# Altium schematic sheet ESSICC-IN-INV1.SchDoc(ESSICC-IN-INV1)
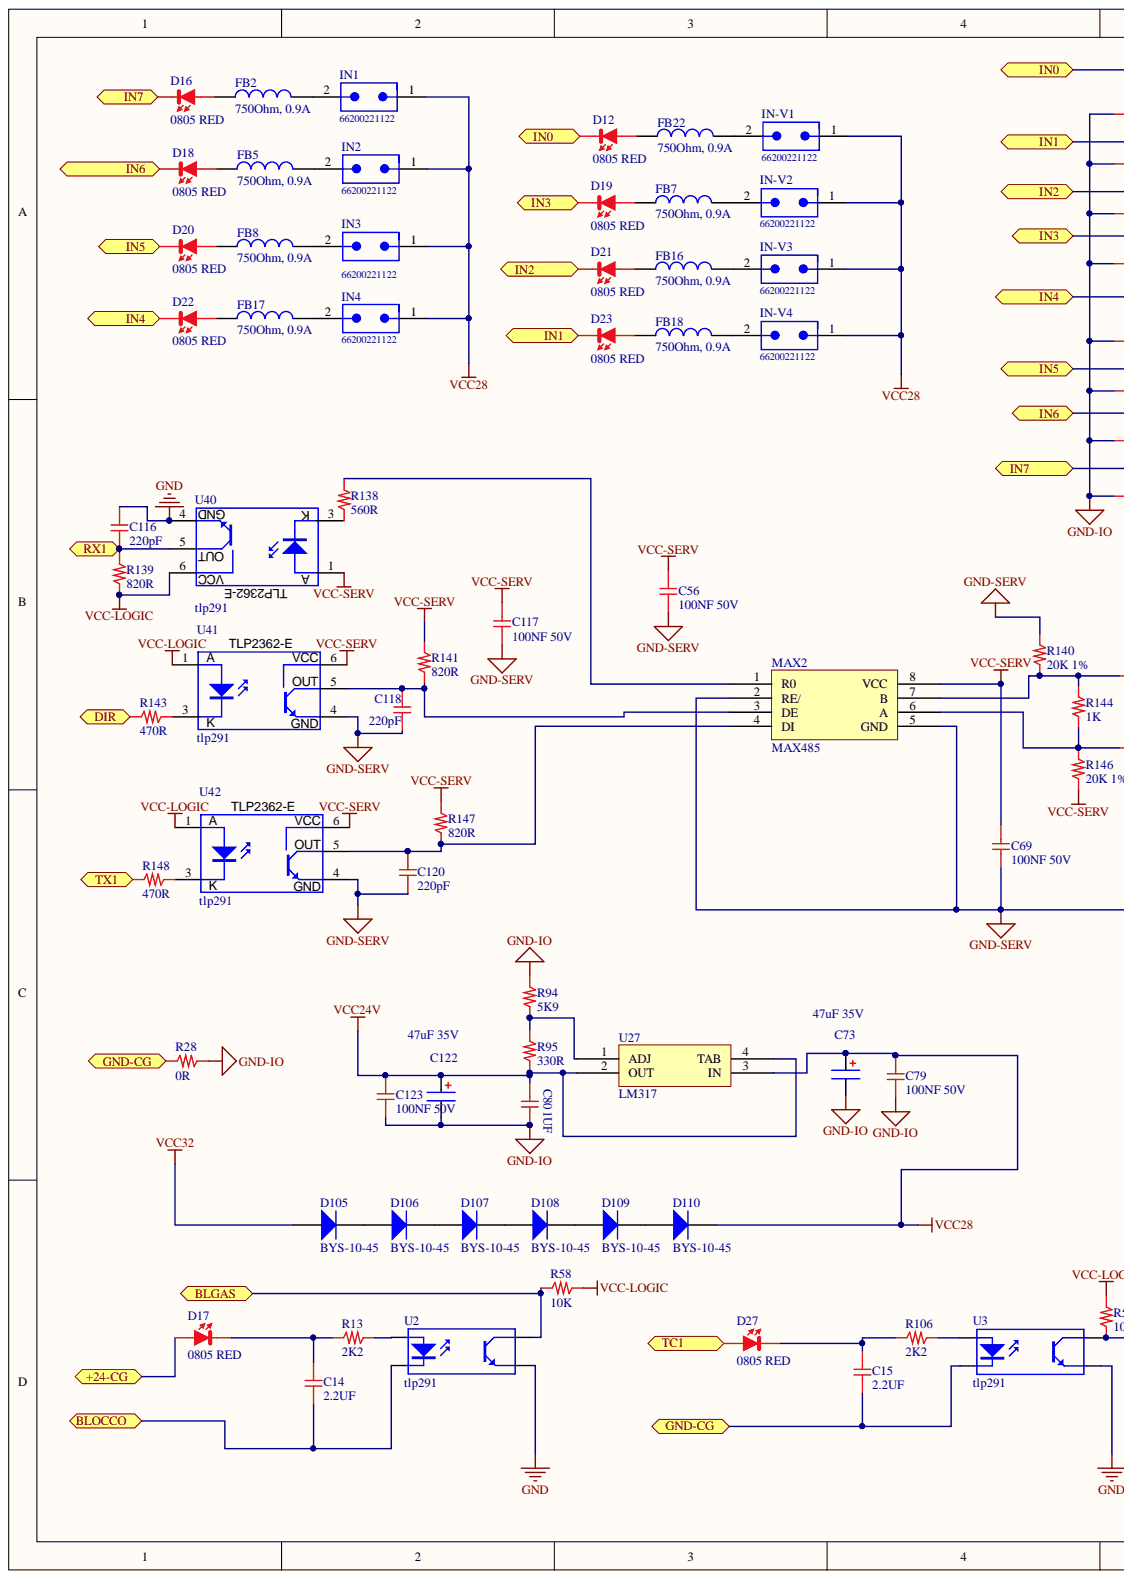
[diagram p0: ESSICC-IN-INV1.SchDoc, left]
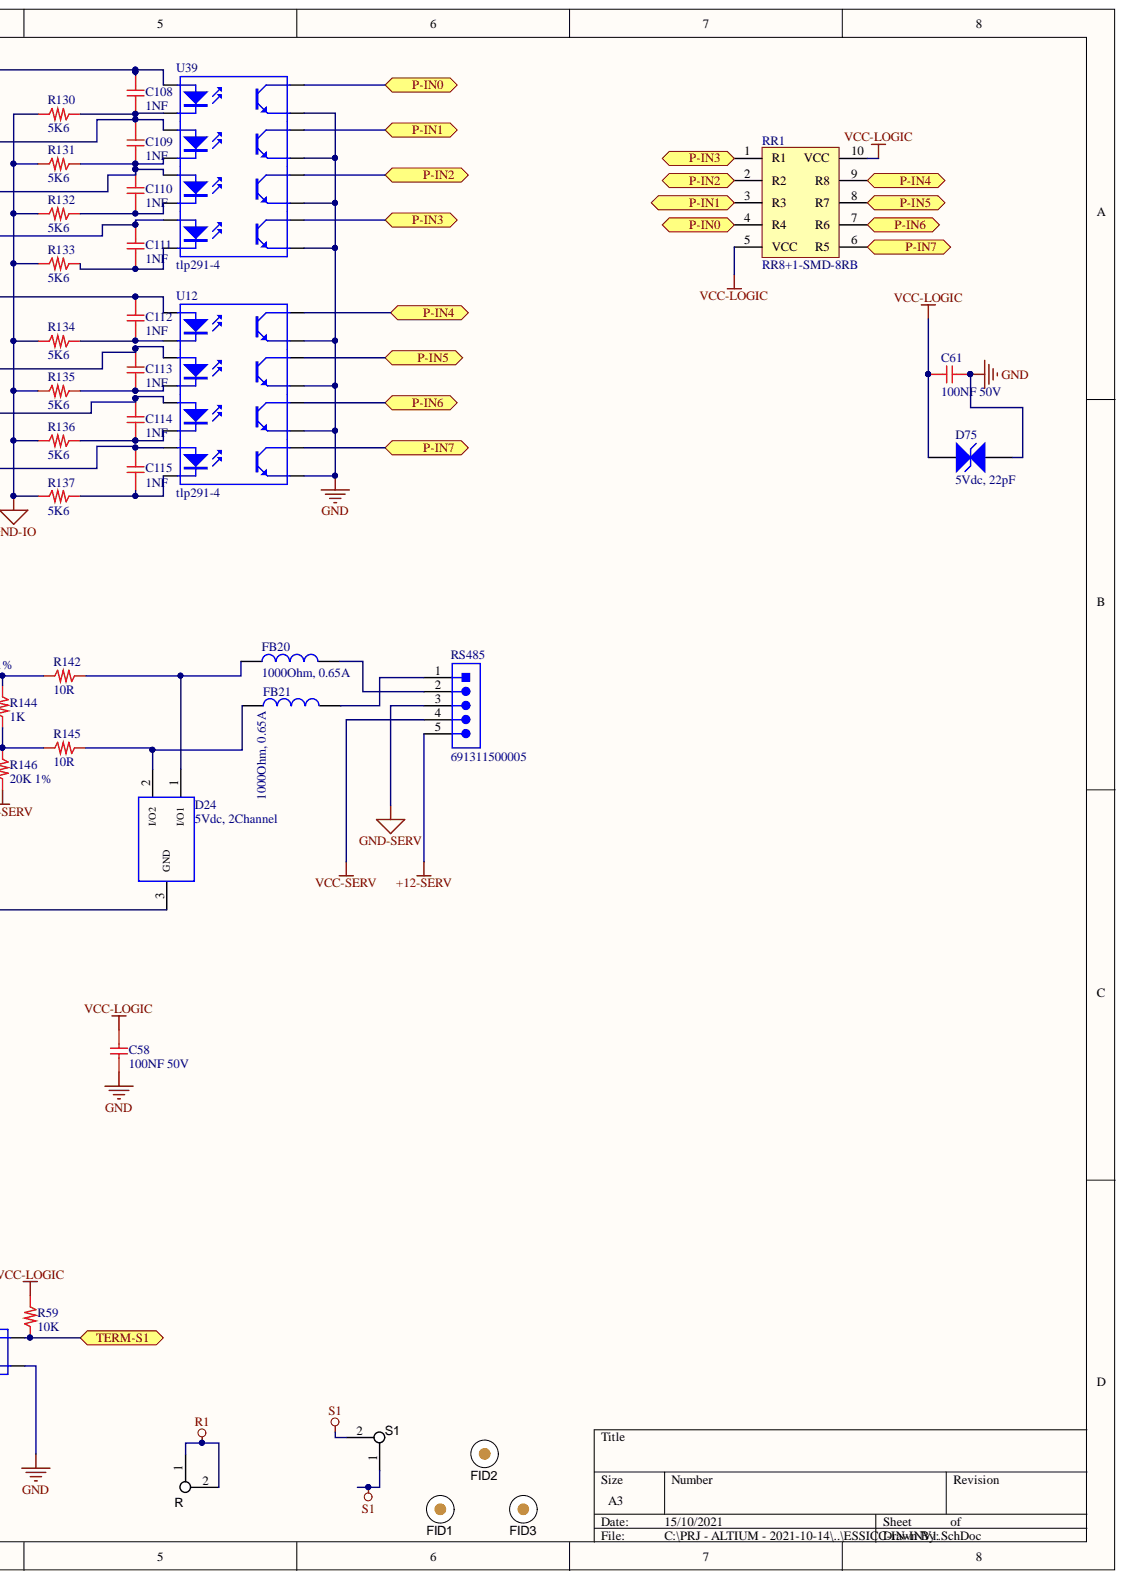
[diagram p1: ESSICC-IN-INV1.SchDoc, right]

## Parts
C14  2.2UF  [1206]
C15  2.2UF  [1206]
C56  100NF 50V  [0603_c]
C58  100NF 50V  [0603_c]
C61  100NF 50V  [0603_c]
C69  100NF 50V  [0603_c]
C73  47uF 35V  Tensione nominale: 35V  Capacità: 47uF  [6.3X5.8]
C79  100NF 50V  [0603_c]
C80  1UF  [0805_C]  (value not drawn)
C108  1NF  [0402_C]
C109  1NF  [0402_C]
C110  1NF  [0402_C]
C111  1NF  [0402_C]
C112  1NF  [0402_C]
C113  1NF  [0402_C]
C114  1NF  [0402_C]
C115  1NF  [0402_C]
C116  220pF  [0402_C]
C117  100NF 50V  [0603_c]
C118  220pF  [0402_C]
C120  220pF  [0402_C]
C122  47uF 35V  Tensione nominale: 35V  Capacità: 47uF  [6.3X5.8]
C123  100NF 50V  [0603_c]
D12  0805 RED  [0805_Red]
D16  0805 RED  [0805_Red]
D17  0805 RED  [0805_Red]
D18  0805 RED  [0805_Red]
D19  0805 RED  [0805_Red]
D20  0805 RED  [0805_Red]
D21  0805 RED  [0805_Red]
D22  0805 RED  [0805_Red]
D23  0805 RED  [0805_Red]
D24  5Vdc, 2Channel  [WE-TVS_Standard_SOT23-3L]
D27  0805 RED  [0805_Red]
D75  5Vdc, 22pF  Capacitance: 22pF  [WE-VE_0603]
D105  BYS-10-45  [DO-214AC -B]
D106  BYS-10-45  [DO-214AC -B]
D107  BYS-10-45  [DO-214AC -B]
D108  BYS-10-45  [DO-214AC -B]
D109  BYS-10-45  [DO-214AC -B]
D110  BYS-10-45  [DO-214AC -B]
FB2  750Ohm, 0.9A  Rated Current: 0.9A  [WE-CBF_0603_Wide Band]
FB5  750Ohm, 0.9A  Rated Current: 0.9A  [WE-CBF_0603_Wide Band]
FB7  750Ohm, 0.9A  Rated Current: 0.9A  [WE-CBF_0603_Wide Band]
FB8  750Ohm, 0.9A  Rated Current: 0.9A  [WE-CBF_0603_Wide Band]
FB16  750Ohm, 0.9A  Rated Current: 0.9A  [WE-CBF_0603_Wide Band]
FB17  750Ohm, 0.9A  Rated Current: 0.9A  [WE-CBF_0603_Wide Band]
FB18  750Ohm, 0.9A  Rated Current: 0.9A  [WE-CBF_0603_Wide Band]
FB20  1000Ohm, 0.65A  Rated Current: 0.65A  [WE-CBF_0603_Wide Band]
FB21  1000Ohm, 0.65A  Rated Current: 0.65A  [WE-CBF_0603_Wide Band]
FB22  750Ohm, 0.9A  Rated Current: 0.9A  [WE-CBF_0603_Wide Band]
FID1  Fiducial  [Fiducial10-20]  (value not drawn)
FID2  Fiducial  [Fiducial10-20]  (value not drawn)
FID3  Fiducial  [Fiducial10-20]  (value not drawn)
IN1  66200221122  [66200221122]
IN2  66200221122  [66200221122]
IN3  66200221122  [66200221122]
IN4  66200221122  [66200221122]
IN-V1  66200221122  [66200221122]
IN-V2  66200221122  [66200221122]
IN-V3  66200221122  [66200221122]
IN-V4  66200221122  [66200221122]
MAX2  MAX485  [SO-8]
R  5012  [FASTOM-TERRA]  (value not drawn)
R13  2K2  [1206_R]
R28  0R  [0805_R]
R58  10K  [0603_R]
R59  10K  [0603_R]
R94  5K9  [0805_R]
R95  330R  [0805_R]
R106  2K2  [1206_R]
R130  5K6  [1206_R]
R131  5K6  [1206_R]
R132  5K6  [1206_R]
R133  5K6  [1206_R]
R134  5K6  [1206_R]
R135  5K6  [1206_R]
R136  5K6  [1206_R]
R137  5K6  [1206_R]
R138  560R  [0603_R]
R139  820R  [0402_R]
R140  20K 1%  [0402_R]
R141  820R  [0402_R]
R142  10R  [0805_R]
R143  470R  [0603_R]
R144  1K  [0805_R]
R145  10R  [0805_R]
R146  20K 1%  [0402_R]
R147  820R  [0402_R]
R148  470R  [0603_R]
RR1  RR8+1-SMD-8RB  [RR8+1-SMD]
RS485  691311500005  [691311500005]
S1  5012  [FASTOM-TERRA]  (value not drawn)
U2  tlp291  [SO4_N]
U3  tlp291  [SO4_N]
U12  tlp291-4  [SO16_NO]
U27  LM317  [SOT-223 - LM317]
U39  tlp291-4  [SO16_NO]
U40  tlp291  [TLP2362-E]
U41  tlp291  [TLP2362-E]
U42  tlp291  [TLP2362-E]

## Ports
+24-CG, BLGAS, BLOCCO, DIR, GND-CG, IN0, IN1, IN2, IN3, IN4, IN5, IN6, IN7, P-IN0, P-IN1, P-IN2, P-IN3, P-IN4, P-IN5, P-IN6, P-IN7, RX1, TC1, TERM-S1, TX1

## Nets
+12-SERV: RS485.5
GND: C58.2 C61.2 C116.1 D75.1 U2.3 U3.3 U12.9 U12.11 U12.13 U12.15 U39.9 U39.11 U39.13 U39.15 U40.4
GND-IO: C73.2 C79.2 C80.2 C122.2 C123.2 R28.2 R94.1 R130.1 R131.1 R132.1 R133.1 R134.1 R135.1 R136.1 R137.1
GND-SERV: C56.2 C69.2 C117.2 C118.2 C120.2 D24.3 MAX2.2(RE/) MAX2.5(GND) R140.1 RS485.3 U41.4 U42.4
R1: R.1 R.2
S1: S1.1 S1.2
VCC24V: C80.1 C122.1 C123.1 R95.2 U27.2(OUT) U27.4(TAB)
VCC28: C73.1 C79.1 D110.1 IN1.1 IN2.1 IN3.1 IN4.1 IN-V1.1 IN-V2.1 IN-V3.1 IN-V4.1 U27.3(IN)
VCC32: D105.2
VCC-LOGIC: C58.1 C61.1 D75.2 R58.2 R59.2 R139.2 RR1.5(VCC) RR1.10(VCC) U40.6 U41.1 U42.1
VCC-SERV: C56.1 C69.1 C117.1 MAX2.8(VCC) R141.1 R146.2 R147.1 RS485.4 U40.1 U41.6 U42.6
+24-CG: D17.A ; port +24-CG
BLGAS: R58.1 U2.4 ; port BLGAS
BLOCCO: C14.2 U2.2 ; port BLOCCO
DIR: R143.1 ; port DIR
GND-CG: C15.2 R28.1 U3.2 ; port GND-CG
IN0: C108.1 D12.K U39.1 ; port IN0
IN1: C109.1 D23.K U39.3 ; port IN1
IN2: C110.1 D21.K U39.5 ; port IN2
IN3: C111.1 D19.K U39.7 ; port IN3
IN4: C112.1 D22.K U12.1 ; port IN4
IN5: C113.1 D20.K U12.3 ; port IN5
IN6: C114.1 D18.K U12.5 ; port IN6
IN7: C115.1 D16.K U12.7 ; port IN7
P-IN0: RR1.4(R4) U39.16 ; port P-IN0
P-IN1: RR1.3(R3) U39.14 ; port P-IN1
P-IN2: RR1.2(R2) U39.12 ; port P-IN2
P-IN3: RR1.1(R1) U39.10 ; port P-IN3
P-IN4: RR1.9(R8) U12.16 ; port P-IN4
P-IN5: RR1.8(R7) U12.14 ; port P-IN5
P-IN6: RR1.7(R6) U12.12 ; port P-IN6
P-IN7: RR1.6(R5) U12.10 ; port P-IN7
RX1: C116.2 R139.1 U40.5 ; port RX1
TC1: D27.A ; port TC1
TERM-S1: R59.1 U3.4 ; port TERM-S1
TX1: R148.1 ; port TX1
NetC14_1: C14.1 D17.K R13.2
NetC15_1: C15.1 D27.K R106.1
NetC108_2: C108.2 R130.2 U39.2
NetC109_2: C109.2 R131.2 U39.4
NetC110_2: C110.2 R132.2 U39.6
NetC111_2: C111.2 R133.2 U39.8
NetC112_2: C112.2 R134.2 U12.2
NetC113_2: C113.2 R135.2 U12.4
NetC114_2: C114.2 R136.2 U12.6
NetC115_2: C115.2 R137.2 U12.8
NetC118_1: C118.1 MAX2.3(DE) R141.2 U41.5
NetC120_1: C120.1 MAX2.4(DI) R147.2 U42.5
NetD12_A: D12.A FB22.1
NetD16_A: D16.A FB2.1
NetD18_A: D18.A FB5.1
NetD19_A: D19.A FB7.1
NetD20_A: D20.A FB8.1
NetD21_A: D21.A FB16.1
NetD22_A: D22.A FB17.1
NetD23_A: D23.A FB18.1
NetD24_1: D24.1 FB20.1 R142.2
NetD24_2: D24.2 FB21.1 R145.2
NetD105_1: D105.1 D106.2
NetD106_1: D106.1 D107.2
NetD107_1: D107.1 D108.2
NetD108_1: D108.1 D109.2
NetD109_1: D109.1 D110.2
NetFB2_2: FB2.2 IN1.2
NetFB5_2: FB5.2 IN2.2
NetFB7_2: FB7.2 IN-V2.2
NetFB8_2: FB8.2 IN3.2
NetFB16_2: FB16.2 IN-V3.2
NetFB17_2: FB17.2 IN4.2
NetFB18_2: FB18.2 IN-V4.2
NetFB20_2: FB20.2 RS485.2
NetFB21_2: FB21.2 RS485.1
NetFB22_2: FB22.2 IN-V1.2
NetMAX2_1: MAX2.1(R0) R138.2
NetMAX2_6: MAX2.6(A) R144.1 R145.1 R146.1
NetMAX2_7: MAX2.7(B) R140.2 R142.1 R144.2
NetR13_1: R13.1 U2.1
NetR94_2: R94.2 R95.1 U27.1(ADJ)
NetR106_2: R106.2 U3.1
NetR138_1: R138.1 U40.3
NetR143_2: R143.2 U41.3
NetR148_2: R148.2 U42.3

## Library data of the parts
C14 C15 = 2.2UF 55V: Cap X5R 1UF 55V; Produttore: MURATA; Cod. Produttore: SCMD722FKR_21; Temperature Range: Da -55°C a +125°C; Library Name: CapSMD1206.SchLib; Component Kind: Standard
C56 C58 C61 C69 C79 C117 C123 = 100NF 50V: Cap X7R 100nF 50V Flex Terminal; Produttore: Samsung; Cod. Produttore: CL10B104KB8SFNC; Cod. Interno: COCS50665050104; Library Name: CapSMD0603.SchLib; Component Kind: Standard; Cod. DigiKey: 1276-1936-2-ND
C73 C122 = 47uF 35V: C-EL-VERT -SMD; Produttore: PANASONIC; ESR: 0,08ohm; Durata: 1000 ORE; Cod. Produttore: EEHZA1V470P; Cod. Interno: ??; Temperature Range: 105°C; Component Kind: Standard; Cod. Farnell: 1907243; Case: D 6.3X5.8; Library Name: CapSMDElect.SchLib
C80 = 1UF 100V: Cap X7S 1UF 100V; Produttore: TDK; Cod. Produttore: C2012X7S2A105K125AE; Cod. Interno: COCS 567061010105; Temperature Range: Da -55°C a +125°C; Library Name: CapSMD0805.SchLib; Component Kind: Standard; Cod. DigiKey: 445-8887-2-ND
C108-C115 = 1NF 50V: Cap X7R 1NF 50V; Produttore: ??; Cod. Interno: COCS556565000102; Library Name: CapSMD0402.SchLib; Component Kind: Standard; Cod. Produttore: ??
C116 C118 C120 = 220pF 50V: Cap COG 220pF 50V; Produttore: ??; Cod. Interno: COCS506565000221; Library Name: CapSMD0402.SchLib; Component Kind: Standard; Cod. Produttore: ??
D12 D16-D23 D27 = LED_ROSSO_0805: LED Rosso 0805; V: 2.0V; Produttore: WE; Luminosità: 150mcd; Cod. Produttore: 150080RS75000; Cod. Interno: LEDS 2AC080RS7500; Component Kind: Standard; Library Name: DiodiLED.SchLib
D24 = 824022: WE-TVS TVS Diode - Standard Series, SOT23-3L, 5Vdc, 2 Channel; Reverse Peak Pulse Current: 8A; Reverse Channel Leakage Current: 2.5uA; Reverse Breakdown Voltage min.: 6.1V; DC Operating Voltage: 5V; Channel Input Capacitance: 12pF; Channel: 2; Size: SOT23-3L; Published Date: June 2019; Part Number: 824022; Packaging: Tape and Reel; Operating Temperature: -55 to +125degC; Mounting Technology: SMT; Library Name: WE-TVS.SchLib; ComponentLink2URL: https://katalog.we-online.com/pbs/datasheet/824022.pdf; ComponentLink2Description: Datasheet; ComponentLink1URL: http://www.we-online.de; ComponentLink1Description: Manufacturer; Component Kind: Standard; Publisher: Wurth Elektronik
D75 = 82356050220: WE-VE ESD Suppressor, size 0603, 5Vdc, 22pF; Leakage Current: 1uA; ESD Voltage air discharge: 15kV; Clamp Voltage: 55V; Working Voltage: 5Vdc; Size: 0603; Published Date: June 2019; Part Number: 82356050220; Packaging: Tape and Reel; Operating Temperature: -40 to +85degC; Mounting Technology: SMT; Library Name: WE-VE_0603.SchLib; ComponentLink2URL: https://katalog.we-online.com/pbs/datasheet/82356050220.pdf; ComponentLink2Description: Datasheet; ComponentLink1URL: http://www.we-online.de; ComponentLink1Description: Manufacturer; Component Kind: Standard; Publisher: Wurth Elektronik
D105-D110 = BYS-10-45: High Conductance Fast Diode; Published: 8-Jun-2000; PackageReference: DO-35; PackageDescription: Diode, Axial; Body 3.8 x 1.9 mm (LxDia. max), Lead Dia. 0.53 mm (max); LatestRevisionNote: Re-released for DXP Platform.; LatestRevisionDate: 17-Jul-2002; DatasheetVersion: 1997; ComponentLink2URL: http://www.fairchildsemi.com/ds/1N%2F1N4148.pdf; ComponentLink2Description: Datasheet; ComponentLink1URL: http://www.fairchildsemi.com/; ComponentLink1Description: Manufacturer Link; Component Kind: Standard; Code_JEDEC: DO-204-AH; Publisher: Altium Limited; Library Name: HSW.SchLib
FB2 FB5 FB7 FB8 FB16-FB18 FB22 = 742792656: WE-CBF SMT EMI Suppression Ferrite Bead, size 0603, 750Ohm, 0.9A; Type: Wide Band; Impedance max.: 800Ohm; DC Resistance max.: 0.35Ohm; Size: 0603; Published Date: March 2019; Part Number: 742792656; Packaging: Tape and Reel; Operating Temperature: -55 to +125degC; Mounting Technology: SMT; Library Name: WE-CBF_0603.SchLib; Impedance@100 MHz: 750Ohm; ComponentLink2URL: https://katalog.we-online.com/pbs/datasheet/742792656.pdf; ComponentLink2Description: Datasheet; ComponentLink1URL: http://www.we-online.de; ComponentLink1Description: Manufacturer; Component Kind: Standard; Publisher: Wurth Elektronik
FB20 FB21 = 74279266: WE-CBF SMT EMI Suppression Ferrite Bead, size 0603, 1000Ohm, 0.65A; Type: Wide Band; Impedance max.: 1350Ohm; DC Resistance max.: 0.6Ohm; Size: 0603; Published Date: March 2019; Part Number: 74279266; Packaging: Tape and Reel; Operating Temperature: -55 to +125degC; Mounting Technology: SMT; Library Name: WE-CBF_0603.SchLib; Impedance@100 MHz: 1000Ohm; ComponentLink2URL: https://katalog.we-online.com/pbs/datasheet/74279266.pdf; ComponentLink2Description: Datasheet; ComponentLink1URL: http://www.we-online.de; ComponentLink1Description: Manufacturer; Component Kind: Standard; Publisher: Wurth Elektronik
FID1-FID3 = Fiducial: Fiducial mark.  There is nothing to buy or mount.; SymbolCreator: codlsc; SymbolVersion: 2009-11-4; PartNumber: N/A; PackageReference: N/A; MountType: N/A; Manufacturer: N/A; LatestRevisionNote: Added Alternate PartNumber and Alternate Manufacturer parameters to appropriate components.; LatestRevisionDate: 2015-10-18; CompType: Fiducial; Component Kind: Standard; ClassName: FiducialMark; Publisher: Texas Instruments; Library Name: HSW.SchLib
IN1-IN4 IN-V1-IN-V4 = 66200221122: THT Male Header WR-MPC3, Vertical, Dual Row, pitch 3 mm, 2 pins; Working Voltage: 250 VAC; Pitch: 3 mm; Pin Number: 2; Contact Resistance: 10 mOhm; Published Date: September 2018; Part Number: 66200221122; Packaging: Tube; Orientation: Vertical; Operating Temperature: -40 to 105 degC; Mounting Technology: THT; Library Name: WR-MPC3.SchLib; Gender: Male; ComponentLink2URL: https://katalog.we-online.de/em/datasheet/6620xx21122.pdf; ComponentLink2Description: Datasheet; ComponentLink1URL: http://www.we-online.de; ComponentLink1Description: Manufacturer; Component Kind: Standard; Publisher: Wurth Elektronik
R S1 = TERRA: Test Point, Multipurpose, White, TH; Manufacturer: Keystone; ContactFinish: Silver; CompType: Test Point Loop; SymbolVersion: 2008-04-04; Supplier Part Number 2: 534-5012; Supplier Part Number 1: 36-5012-ND; Supplier 2: Mouser; Supplier 1: Digi-Key; RoHS: Y; PartNumber: 5012; PackageReference: White Multipurpose Testpoint; MountType: TH; LatestRevisionDate: 2017-01-19; ComponentLink1URL: http://www.keyelco.com/userAssets/file/M60-2p60.pdf; ComponentLink1Description: Datasheet URL; Component Kind: Standard; Color: White; Publisher: Texas Instruments; Library Name: HSW.SchLib
R13 = 10K: 4K7 1206 1/4W; Library Name: ResSMD1206.SchLib; Component Kind: Standard; Case: 1206
R28 = 0R: Res Film Spesso 1/2W 0R; Potenza: 1/2W 5%; Cod. Interno: RESS4170555B0000; Library Name: ResSMD0805.SchLib; Component Kind: Standard
R58 R59 = 10K: Res Film Spesso 1/16W 10K 5%; Produttore: ??; Cod. Interno: RESS4166556B0103??; Library Name: ResSMD0603.SchLib; Component Kind: Standard; Cod. Produttore: ??
R94 R144 = 1K: Res Film Spesso 1/2W 1K 5%; Potenza: 1/2W 5%; Cod. Interno: RESS4170555B0122??; Library Name: ResSMD0805.SchLib; Component Kind: Standard
R95 = 1K: 330R-0805; Potenza: 1/2W 5%; Cod. Interno: RESS4170555B0122??; Library Name: ResSMD0805.SchLib; Component Kind: Standard
R106 R130-R137 = 2K2: 2K2 1206 1/4W; Library Name: ResSMD1206.SchLib; Component Kind: Standard; Case: 1206
R138 = 560R: Res Film Spesso 1/16W 560R 5%; Cod. Interno: RESS 4166556B0561; Library Name: ResSMD0603.SchLib; Component Kind: Standard
R139 R141 R147 = 820R: Res Film Spesso 1/16W 820R 5%; Produttore: ??; Cod. Interno: RESS4166560C0121; Library Name: ResSMD0402.SchLib; Component Kind: Standard; Cod. Produttore: ??
R140 R146 = 20K 1%: Res Film Spesso 1/16W 20K 1%; Produttore: ??; Cod. Interno: RESS4165360C0223; Library Name: ResSMD0402.SchLib; Component Kind: Standard; Cod. Produttore: ??
R142 R145 = 10R: Res Film Spesso 1/8W 10R 5%; Potenza: 1/8W 5%; Cod. Interno: RESS4170555B0100; Library Name: ResSMD0805.SchLib; Component Kind: Standard
R143 R148 = 470R: Res Film Spesso 1/16W 470R 5%; Produttore: ??; Cod. Interno: RESS4166556B0471??; Library Name: ResSMD0603.SchLib; Component Kind: Standard; Cod. Produttore: ??
RS485 = 691311500005: WR-TBL Serie 311 Open Vertical PCB Header, Pluggable, pitch 5.08mm, 5p; Working Voltage [UL]: 300VAC; Rated Current [UL]: 20A; Pin Number: 5; Modularity: No; Compliance: RoHS; Type: Vertical; Published Date: October 2019; Pitch: 5.08mm; PCB/Cable/Panel: PCB; Part Number: 691311500005; Packaging: Box; Operating Temperature: -40 to +105degC; Mounting Technology: THT; Library Name: WR-TBL_Pluggable PCB Header.SchLib; ComponentLink2URL: https://katalog.we-online.de/em/datasheet/691311500005.pdf; ComponentLink2Description: Datasheet; ComponentLink1URL: http://www.we-online.de; ComponentLink1Description: Manufacturer; Component Kind: Standard; Application: Pluggable; Publisher: Wurth Elektronik
U2 U3 = tlp291: 4-Pin Phototransistor Optocoupler; Published: 24-Mar-1999; PackageReference: DIP-4; LatestRevisionNote: Sch pins 3 & 4 Swapped.; LatestRevisionDate: 04-Mar-2005; DatasheetVersion: Nov-2003; Component Kind: Standard; Publisher: Altium Limited; Library Name: HSW.SchLib
U12 U39 = tlp291_4: 4-Pin Phototransistor Optocoupler; Published: 24-Mar-1999; PackageReference: DIP-4; LatestRevisionNote: Sch pins 3 & 4 Swapped.; LatestRevisionDate: 04-Mar-2005; DatasheetVersion: Nov-2003; Component Kind: Standard; Publisher: Altium Limited; Library Name: HSW.SchLib
U27 = LM317: REGOLATORE-ADJ.; Component Kind: Standard; Library Name: HSW.SchLib
U40-U42 = TLP2362-E: 4-Pin Phototransistor Optocoupler; Published: 24-Mar-1999; PackageReference: DIP-4; LatestRevisionNote: Sch pins 3 & 4 Swapped.; LatestRevisionDate: 04-Mar-2005; DatasheetVersion: Nov-2003; Component Kind: Standard; Publisher: Altium Limited; Library Name: HSW.SchLib
MAX2 = MAX485: Component Kind: Standard; Library Name: HSW.SchLib
RR1 = RR8+1-SMD-8RB: Component Kind: Standard; Library Name: HSW.SchLib
